# Altium schematic sheet AquaboxII_2.SchDoc
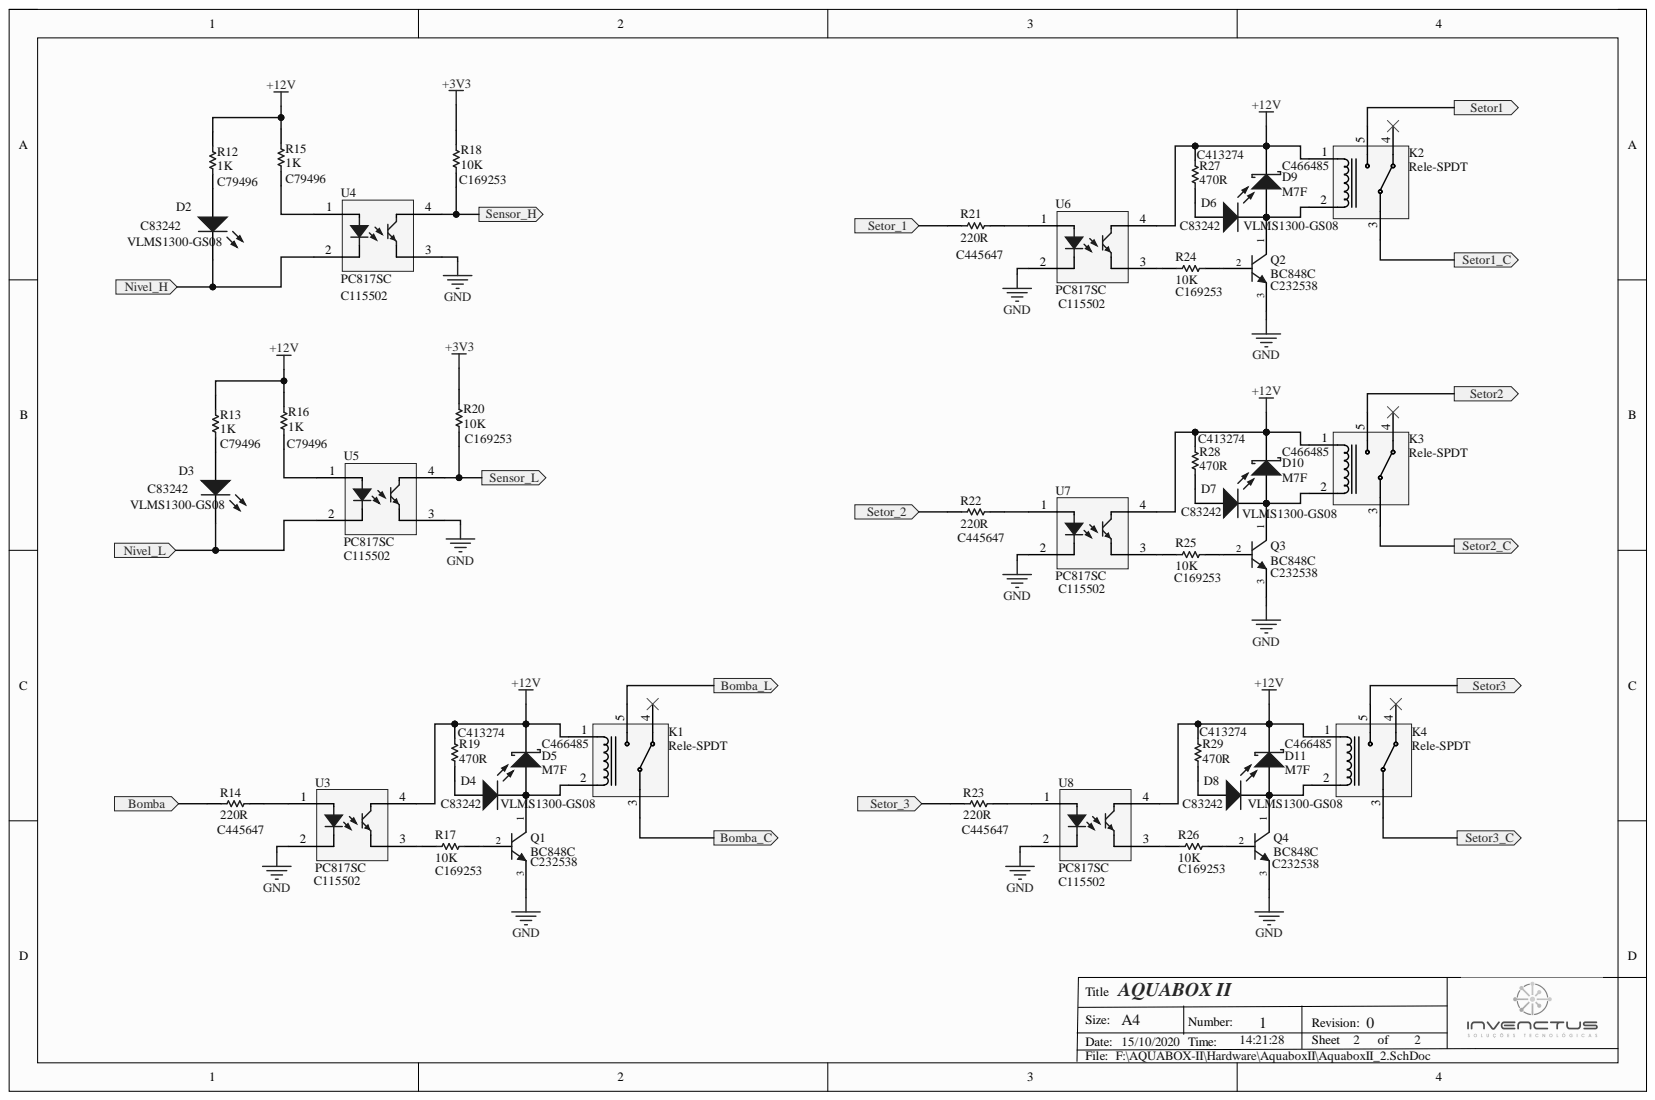
[diagram p0: AquaboxII_2.SchDoc]

## Parts
D2  VLMS1300-GS08  Supplier Part Number 1: C83242  [LED-0603-R]
D3  VLMS1300-GS08  Supplier Part Number 1: C83242  [LED-0603-R]
D4  VLMS1300-GS08  Supplier Part Number 1: C83242  [LED-0603-R]
D5  M7F  Supplier Part Number 1: C466485  [DO214AC]
D6  VLMS1300-GS08  Supplier Part Number 1: C83242  [LED-0603-R]
D7  VLMS1300-GS08  Supplier Part Number 1: C83242  [LED-0603-R]
D8  VLMS1300-GS08  Supplier Part Number 1: C83242  [LED-0603-R]
D9  M7F  Supplier Part Number 1: C466485  [DO214AC]
D10  M7F  Supplier Part Number 1: C466485  [DO214AC]
D11  M7F  Supplier Part Number 1: C466485  [DO214AC]
K1  Rele-SPDT  [RELE-SPDT]
K2  Rele-SPDT  [RELE-SPDT]
K3  Rele-SPDT  [RELE-SPDT]
K4  Rele-SPDT  [RELE-SPDT]
Q1  BC848C  Supplier Part Number 1: C232538  [SOT23]
Q2  BC848C  Supplier Part Number 1: C232538  [SOT23]
Q3  BC848C  Supplier Part Number 1: C232538  [SOT23]
Q4  BC848C  Supplier Part Number 1: C232538  [SOT23]
R12  1K  Supplier Part Number 1: C79496  [R2012[0805]]
R13  1K  Supplier Part Number 1: C79496  [R2012[0805]]
R14  220R  Supplier Part Number 1: C445647  [R2012[0805]]
R15  1K  Supplier Part Number 1: C79496  [R2012[0805]]
R16  1K  Supplier Part Number 1: C79496  [R2012[0805]]
R17  10K  [R2012[0805]]
R18  10K  Supplier Part Number 1: C169253  [R2012[0805]]
R19  470R  Supplier Part Number 1: C413274  [R2012[0805]]
R20  10K  Supplier Part Number 1: C169253  [R2012[0805]]
R21  220R  Supplier Part Number 1: C445647  [R2012[0805]]
R22  220R  Supplier Part Number 1: C445647  [R2012[0805]]
R23  220R  Supplier Part Number 1: C445647  [R2012[0805]]
R24  10K  [R2012[0805]]
R25  10K  [R2012[0805]]
R26  10K  [R2012[0805]]
R27  470R  Supplier Part Number 1: C413274  [R2012[0805]]
R28  470R  Supplier Part Number 1: C413274  [R2012[0805]]
R29  470R  Supplier Part Number 1: C413274  [R2012[0805]]
U3  PC817SC  Supplier Part Number 1: C115502  [LITEON LTV-817]
U4  PC817SC  LCSC Part: C115502  [LITEON LTV-817]
U5  PC817SC  Supplier Part Number 1: C115502  [LITEON LTV-817]
U6  PC817SC  Supplier Part Number 1: C115502  [LITEON LTV-817]
U7  PC817SC  Supplier Part Number 1: C115502  [LITEON LTV-817]
U8  PC817SC  Supplier Part Number 1: C115502  [LITEON LTV-817]

## Ports
Bomba, Bomba_C, Bomba_L, Nivel_H, Nivel_L, Sensor_H, Sensor_L, Setor1, Setor1_C, Setor2, Setor2_C, Setor3, Setor3_C, Setor_1, Setor_2, Setor_3

## Nets
+3V3: R18.2 R20.2
+12V: D5.2 D9.2 D10.2 D11.2 K1.1 K2.1 K3.1 K4.1 R12.2 R13.2 R15.2 R16.2 R19.1 R27.1 R28.1 R29.1 U3.4 U6.4 U7.4 U8.4
GND: Q1.3 Q2.3 Q3.3 Q4.3 U3.2 U4.3 U5.3 U6.2 U7.2 U8.2
Bomba: R14.2 ; port Bomba
Bomba_C: K1.3 ; port Bomba_C
Bomba_L: K1.5 ; port Bomba_L
Nivel_H: D2.2 U4.2 ; port Nivel_H
Nivel_L: D3.2 U5.2 ; port Nivel_L
Sensor_H: R18.1 U4.4 ; port Sensor_H
Sensor_L: R20.1 U5.4 ; port Sensor_L
Setor1: K2.5 ; port Setor1
Setor1_C: K2.3 ; port Setor1_C
Setor2: K3.5 ; port Setor2
Setor2_C: K3.3 ; port Setor2_C
Setor3: K4.5 ; port Setor3
Setor3_C: K4.3 ; port Setor3_C
Setor_1: R21.2 ; port Setor_1
Setor_2: R22.2 ; port Setor_2
Setor_3: R23.2 ; port Setor_3
NetD2_1: D2.1 R12.1
NetD3_1: D3.1 R13.1
NetD4_1: D4.1 R19.2
NetD4_2: D4.2 D5.1 K1.2 Q1.1
NetD6_1: D6.1 R27.2
NetD6_2: D6.2 D9.1 K2.2 Q2.1
NetD7_1: D7.1 R28.2
NetD7_2: D7.2 D10.1 K3.2 Q3.1
NetD8_1: D8.1 R29.2
NetD8_2: D8.2 D11.1 K4.2 Q4.1
NetQ1_2: Q1.2 R17.1
NetQ2_2: Q2.2 R24.1
NetQ3_2: Q3.2 R25.1
NetQ4_2: Q4.2 R26.1
NetR14_1: R14.1 U3.1
NetR15_1: R15.1 U4.1
NetR16_1: R16.1 U5.1
NetR17_2: R17.2 U3.3
NetR21_1: R21.1 U6.1
NetR22_1: R22.1 U7.1
NetR23_1: R23.1 U8.1
NetR24_2: R24.2 U6.3
NetR25_2: R25.2 U7.3
NetR26_2: R26.2 U8.3

Not connected: K1 4; K2 4; K3 4; K4 4

## Library data of the parts
D2-D4 D6-D8 = LED: LED Uni-Color Red 639nm 2-Pin Chip LED T/R; Dominant Wavelength: 631nm; Forward Current: 20mA; Forward Voltage: 2V; Lens Style: Rectangular,Clear; Luminous Intensity: 54mcd; Max Power Dissipation: 75mW; Number of Elements: 1; Reverse Voltage: 5V; Viewing Angle: 130°; Case Code (Imperial): 0603; Case Code (Metric): 1608; Case/Package: 0603; Category: LEDs; Color: Red; Component Kind: Standard; ComponentLink1Description: https://datasheet.ciiva.com/2114/vlmo1300-2114929.pdf?src-supplier=Digi-Key; ComponentLink1URL: https://datasheet.ciiva.com/2114/vlmo1300-2114929.pdf?src-supplier=Digi-Key; ComponentLink2Description: https://datasheet.ciiva.com/2114/vlmo1300-2114930.pdf?src-supplier=Digi-Key; ComponentLink2URL: https://datasheet.ciiva.com/2114/vlmo1300-2114930.pdf?src-supplier=Digi-Key; ComponentLink3Description: https://datasheet.ciiva.com/2114/vlmo1300-2114931.pdf?src-supplier=Digi-Key; ComponentLink3URL: https://datasheet.ciiva.com/2114/vlmo1300-2114931.pdf?src-supplier=Digi-Key; ComponentLink4Description: https://datasheet.ciiva.com/16510/vlmo1300-16510985.pdf?src-supplier=Future+Electronics; ComponentLink4URL: https://datasheet.ciiva.com/16510/vlmo1300-16510985.pdf?src-supplier=Future+Electronics; ComponentLink5Description: https://datasheet.ciiva.com/26322/vlmo1300-26322153.pdf?src-supplier=Element14; ComponentLink5URL: https://datasheet.ciiva.com/26322/vlmo1300-26322153.pdf?src-supplier=Element14; ComponentLink6Description: https://datasheet.ciiva.com/8028/vlmo1300-8028047.pdf?src-supplier=Element14; ComponentLink6URL: https://datasheet.ciiva.com/8028/vlmo1300-8028047.pdf?src-supplier=Element14; ComponentLink7Description: https://datasheet.ciiva.com/9333/vlmo1300-9333743.pdf?src-supplier=Avnet; ComponentLink7URL: https://datasheet.ciiva.com/9333/vlmo1300-9333743.pdf?src-supplier=Avnet; Contact Plating: Tin,Matte; Depth: 0.8mm; Height: 0.8mm; Illumination Color: Red; Lead Free: Lead Free; Length: 1.6mm; Library Name: Basic Library.IntLib; Manufacturer Part Number: VLMS1300-GS08; Max Operating Temperature: 85°C; Min Operating Temperature: -35°C; Mount: Surface Mount; Number of LEDs: 1; Number of Pins: 2; Package Quantity: 3000; Packaging: Tape and Reel; Power Dissipation: 75mW; Power Rating: 75mW; Pricing 1: 10=0,07360, 100=0,05470, 300=0,05130, 1000=0,04780, 5000=0,04630, 10000=0,04550, 10=0,06990, 100=0,05190, 300=0,04870, 1000=0,04540, 5000=0,04390, 10000=0,04320, 10=0,35640, 100=0,26490, 300=0,24820, 1000=0,23140, 5000=0,22390, 10000=0,22020, 10=0,33510, 100=0,24920, 300=0,23340, 1000=0,21760, 5000=0,21060, 10000=0,20710, 10=0,04500, 100=0,03350, 300=0,03140, 1000=0,02920, 5000=0,02830, 10000=0,02780, 10=0,04090, 100=0,03040, 300=0,02840, 1000=0,02650, 5000=0,02570, 10000=0,02520, 10=0,41250, 100=0,30670, 300=0,28730, 1000=0,26780, 5000=0,25920, 10000=0,25490, 10=5,62230, 100=4,18010, 300=3,91520, 1000=3,65030, 5000=3,53260, 10000=3,47440, 10=0,22030, 100=0,16380, 300=0,15340, 1000=0,14300, 5000=0,13840, 10000=0,13610, 10=4,09310, 100=3,04310, 300=2,85020, 1000=2,65740, 5000=2,57170, 10000=2,52940, 10=0,46820, 100=0,34810, 300=0,32610, 1000=0,30400, 5000=0,29420, 10000=0,28930, 10=0,07210, 100=0,05360, 300=0,05020, 1000=0,04680, 5000=0,04530, 10000=0,04450, 10=0,05323, 100=0,03958, 300=0,03707, 1000=0,03456, 5000=0,03345, 10000=0,03290 (AUD); Radiation Hardening: No; REACH SVHC: Unknown; RoHS: Yes; Simulation: LED0; Stock 1: 3280; Supplier 1: LCSC; Test Current: 20mA; Wavelength: 631nm; Width: 0.8mm
D5 D9-D11 = D Schottky: MICRO COMMERCIAL COMPONENTS - SM4007PL-TP - Diode mit Standard-Erholzeit, 1 kV, 1 A, Einfach, 525 mV, 25 A; Average Rectified Current: 1A; Element Configuration: Single; Forward Voltage: 1.1V; Max Forward Surge Current (Ifsm): 30A; Max Repetitive Reverse Voltage (Vrrm): 1kV; Max Reverse Current: 5uA; Peak Non-Repetitive Surge Current: 25A; Reverse Recovery Time: 1us; Category: Rectifier Diodes; Component Kind: Standard; ComponentLink1Description: https://datasheet.ciiva.com/4246/1024sm4001pl-sm4007plsod-123fl-4246411.pdf?src-supplier=Arrow+Electronics; ComponentLink1URL: https://datasheet.ciiva.com/4246/1024sm4001pl-sm4007plsod-123fl-4246411.pdf?src-supplier=Arrow+Electronics; ComponentLink2Description: https://datasheet.ciiva.com/917/sm4001pl-sm4007plsod-123fl-917185.pdf?src-supplier=Digi-Key; ComponentLink2URL: https://datasheet.ciiva.com/917/sm4001pl-sm4007plsod-123fl-917185.pdf?src-supplier=Digi-Key; ComponentLink3Description: https://datasheet.ciiva.com/917/sm4001pl-sm4007plsod-123fl-917186.pdf?src-supplier=Digi-Key; ComponentLink3URL: https://datasheet.ciiva.com/917/sm4001pl-sm4007plsod-123fl-917186.pdf?src-supplier=Digi-Key; ComponentLink4Description: https://datasheet.ciiva.com/917/sm4001pl-sm4007plsod-123fl-917187.pdf?src-supplier=Digi-Key; ComponentLink4URL: https://datasheet.ciiva.com/917/sm4001pl-sm4007plsod-123fl-917187.pdf?src-supplier=Digi-Key; ComponentLink5Description: https://datasheet.ciiva.com/7963/1684871-7963317.pdf?src-supplier=Element14; ComponentLink5URL: https://datasheet.ciiva.com/7963/1684871-7963317.pdf?src-supplier=Element14; ComponentLink6Description: https://datasheet.ciiva.com/5763/1684871-5763463.pdf?src-supplier=Farnell; ComponentLink6URL: https://datasheet.ciiva.com/5763/1684871-5763463.pdf?src-supplier=Farnell; ComponentLink7Description: https://datasheet.ciiva.com/24152/sm4001pl-sm4007plsod-123fl-24152055.pdf?src-supplier=Future+Electronics; ComponentLink7URL: https://datasheet.ciiva.com/24152/sm4001pl-sm4007plsod-123fl-24152055.pdf?src-supplier=Future+Electronics; Current: 1A; Forward Current: 1A; Lead Free: Lead Free; Library Name: Diodos.IntLib; Manufacturer Part Number: SM4007PL-TP; Max Operating Temperature: 175°C; Max Reverse Voltage (DC): 1kV; Max Surge Current: 30A; Min Operating Temperature: -65°C; Mount: Surface Mount; Number of Pins: 2; Package Quantity: 1; Packaging: Tape and Reel; Radiation Hardening: No; REACH SVHC: Unknown; Reverse Voltage: 1kV; RoHS: Yes; Signal Integrity: D Schottky; Simulation: SKYDIODE; Supplier 1: LCSC; Voltage: 1kV
Q1-Q4 = NPN: ON SEMICONDUCTOR - BC848CLT1G - Bipolar (BJT) Single Transistor, General Purpose, NPN, 30 V, 100 MHz, 300 mW, 100 mA, 100 hFE; Collector Base Voltage (VCBO): 30V; Collector Emitter Saturation Voltage: 600mV; Current Rating: 100mA; Emitter Base Voltage (VEBO): 5V; Frequency: 100MHz; hFE Min: 420; Max Power Dissipation: 300mW; Number of Elements: 1; Case/Package: SOT-23-3; Category: BJTs; Collector Emitter Breakdown Voltage: 30V; Collector Emitter Voltage (VCEO): 30V; Component Kind: Standard; ComponentLink1Description: https://datasheet.ciiva.com/4722/bc846alt1-d-108909-4722112.pdf?src-supplier=Mouser; ComponentLink1URL: https://datasheet.ciiva.com/4722/bc846alt1-d-108909-4722112.pdf?src-supplier=Mouser; ComponentLink2Description: https://datasheet.ciiva.com/15138/getdatasheetpartid-68998-15138954.pdf?src-supplier=Verical; ComponentLink2URL: https://datasheet.ciiva.com/15138/getdatasheetpartid-68998-15138954.pdf?src-supplier=Verical; ComponentLink3Description: https://datasheet.ciiva.com/976/bc846alt1-d-976404.pdf?src-supplier=Digi-Key; ComponentLink3URL: https://datasheet.ciiva.com/976/bc846alt1-d-976404.pdf?src-supplier=Digi-Key; ComponentLink4Description: https://datasheet.ciiva.com/976/bc846alt1-d-976405.pdf?src-supplier=Digi-Key; ComponentLink4URL: https://datasheet.ciiva.com/976/bc846alt1-d-976405.pdf?src-supplier=Digi-Key; ComponentLink5Description: https://datasheet.ciiva.com/16351/bc846alt1-d-16351381.pdf?src-supplier=Future+Electronics; ComponentLink5URL: https://datasheet.ciiva.com/16351/bc846alt1-d-16351381.pdf?src-supplier=Future+Electronics; ComponentLink6Description: https://datasheet.ciiva.com/976/bc846alt1-d-976406.pdf?src-supplier=Digi-Key; ComponentLink6URL: https://datasheet.ciiva.com/976/bc846alt1-d-976406.pdf?src-supplier=Digi-Key; ComponentLink7Description: https://datasheet.ciiva.com/25998/bc846alt1-d-25998630.pdf?src-supplier=Avnet+Europe; ComponentLink7URL: https://datasheet.ciiva.com/25998/bc846alt1-d-25998630.pdf?src-supplier=Avnet+Europe; ComponentLink8Description: https://datasheet.ciiva.com/11202/bc846alt1-d-11202104.pdf?src-supplier=Avnet; ComponentLink8URL: https://datasheet.ciiva.com/11202/bc846alt1-d-11202104.pdf?src-supplier=Avnet; ComponentLink9Description: https://datasheet.ciiva.com/7971/bc846alt1-d-7971964.pdf?src-supplier=Element14; ComponentLink9URL: https://datasheet.ciiva.com/7971/bc846alt1-d-7971964.pdf?src-supplier=Element14; ComponentLink10Description: https://datasheet.ciiva.com/7971/bc846alt1-d-7971966.pdf?src-supplier=Element14; ComponentLink10URL: https://datasheet.ciiva.com/7971/bc846alt1-d-7971966.pdf?src-supplier=Element14; Contact Plating: Tin,Matte; Element Configuration: Single; Gain Bandwidth Product: 100MHz; Height: 0.94mm; Lead Free: Lead Free; Length: 2.9mm; Library Name: Transistores.IntLib; Manufacturer: ON Semiconductor; Manufacturer Part Number: BC848CLT1G; Max Breakdown Voltage: 30V; Max Collector Current: 100mA; Max Frequency: 100MHz; Max Operating Temperature: 150°C; Min Operating Temperature: -55°C; Number of Pins: 3; Package Quantity: 1; Packaging: Tape and Reel; Polarity: NPN; Power Dissipation: 300mW; Pricing 1: 20=0,02450, 200=0,01770, 600=0,01650, 2000=0,01520, 10000=0,01470, 20000=0,01440, 20=0,02330, 200=0,01680, 600=0,01560, 2000=0,01440, 10000=0,01390, 20000=0,01370, 20=0,11880, 200=0,08580, 600=0,07970, 2000=0,07370, 10000=0,07100, 20000=0,06970, 20=0,11170, 200=0,08070, 600=0,07500, 2000=0,06930, 10000=0,06680, 20000=0,06550, 20=0,01500, 200=0,01080, 600=0,01010, 2000=0,00930, 10000=0,00900, 20000=0,00880, 20=0,01360, 200=0,00980, 600=0,00910, 2000=0,00840, 10000=0,00810, 20000=0,00800, 20=0,13750, 200=0,09930, 600=0,09230, 2000=0,08530, 10000=0,08220, 20000=0,08060, 20=1,87440, 200=1,35380, 600=1,25810, 2000=1,16250, 10000=1,12000, 20000=1,09900, 20=0,07340, 200=0,05300, 600=0,04930, 2000=0,04550, 10000=0,04390, 20000=0,04310, 20=1,36450, 200=0,98550, 600=0,91590, 2000=0,84630, 10000=0,81540, 20000=0,80010, 20=0,15610, 200=0,11270, 600=0,10480, 2000=0,09680, 10000=0,09330, 20000=0,09150, 20=0,02400, 200=0,01740, 600=0,01610, 2000=0,01490, 10000=0,01440, 20000=0,01410, 20=0,01775, 200=0,01282, 600=0,01191, 2000=0,01101, 10000=0,01060, 20000=0,01041 (AUD); Radiation Hardening: No; REACH SVHC: No SVHC; RoHS: Yes; Signal Integrity: NPN; Simulation: NPN; Stock 1: 17320; Supplier 1: LCSC; Transition Frequency: 100MHz; Voltage Rating (DC): 30V; Width: 1.3mm
R12 R13 R15 R16 = R: Res Thick Film 0805 1K Ohm 1% 1/8W ±100ppm/°C Molded SMD Punched Carrier T/R; Manufacturer Part Number: ERJ6ENF1001V; Max Power Dissipation: 125mW; Resistance: 1kR; Value: 0; Voltage Rating: 150V; Case Code (Imperial): 0805; Case Code (Metric): 2012; Case/Package: 0805; Category: Chip SMD Resistors; Component Kind: Standard; ComponentLink1Description: https://datasheet.ciiva.com/34303/1988962-34303619.pdf?src-supplier=Jameco; ComponentLink1URL: https://datasheet.ciiva.com/34303/1988962-34303619.pdf?src-supplier=Jameco; ComponentLink2Description: https://datasheet.ciiva.com/11808/0900766b80f80784-11808500.pdf?src-supplier=RSComponents; ComponentLink2URL: https://datasheet.ciiva.com/11808/0900766b80f80784-11808500.pdf?src-supplier=RSComponents; ComponentLink3Description: https://datasheet.ciiva.com/28532/426256-28532134.pdf?src-supplier=Element14; ComponentLink3URL: https://datasheet.ciiva.com/28532/426256-28532134.pdf?src-supplier=Element14; ComponentLink4Description: https://datasheet.ciiva.com/16774/70260748-16774249.pdf?src-supplier=Allied+Electronics; ComponentLink4URL: https://datasheet.ciiva.com/16774/70260748-16774249.pdf?src-supplier=Allied+Electronics; ComponentLink5Description: https://datasheet.ciiva.com/46373/1678782-46373338.pdf?src-supplier=Element14; ComponentLink5URL: https://datasheet.ciiva.com/46373/1678782-46373338.pdf?src-supplier=Element14; ComponentLink6Description: https://datasheet.ciiva.com/7942/426256-7942429.pdf?src-supplier=Element14; ComponentLink6URL: https://datasheet.ciiva.com/7942/426256-7942429.pdf?src-supplier=Element14; ComponentLink7Description: https://datasheet.ciiva.com/9117/aoa0000ce2-9117391.pdf?src-supplier=Avnet; ComponentLink7URL: https://datasheet.ciiva.com/9117/aoa0000ce2-9117391.pdf?src-supplier=Avnet; ComponentLink8Description: https://datasheet.ciiva.com/16523/aoa0000ce2-16523855.pdf?src-supplier=Avnet+Asia; ComponentLink8URL: https://datasheet.ciiva.com/16523/aoa0000ce2-16523855.pdf?src-supplier=Avnet+Asia; ComponentLink9Description: https://datasheet.ciiva.com/30051/aoa0000ce2-30051974.pdf?src-supplier=Onlinecomponents.com; ComponentLink9URL: https://datasheet.ciiva.com/30051/aoa0000ce2-30051974.pdf?src-supplier=Onlinecomponents.com; ComponentLink10Description: https://datasheet.ciiva.com/16199/aoa0000ce2-16199262.pdf?src-supplier=Future+Electronics; ComponentLink10URL: https://datasheet.ciiva.com/16199/aoa0000ce2-16199262.pdf?src-supplier=Future+Electronics; Contact Plating: Tin; Depth: 1.25mm; Features: Automotive AEC-Q200; Height: 0.6mm; Lead Free: Lead Free; Length: 2mm; Max Operating Temperature: 155°C; Military Standard: Not; Min Operating Temperature: -55°C; Mount: Surface Mount; Number of Pins: 2; Number of Terminations: 2; Package Quantity: 5000; Packaging: Tape and Reel; Power Rating: 125mW; Pricing 1: 50=0,01660, 500=0,01220, 1500=0,01140, 5000=0,01060, 25000=0,01020, 50000=0,01000, 50=0,01570, 500=0,01160, 1500=0,01080, 5000=0,01000, 25000=0,00970, 50000=0,00950, 50=0,08020, 500=0,05900, 1500=0,05510, 5000=0,05110, 25000=0,04940, 50000=0,04850, 50=0,07550, 500=0,05540, 1500=0,05180, 5000=0,04810, 25000=0,04650, 50000=0,04570, 50=0,01010, 500=0,00750, 1500=0,00700, 5000=0,00650, 25000=0,00620, 50000=0,00610, 50=0,00920, 500=0,00680, 1500=0,00630, 5000=0,00590, 25000=0,00570, 50000=0,00560, 50=0,09290, 500=0,06830, 1500=0,06370, 5000=0,05920, 25000=0,05720, 50000=0,05620, 50=1,26610, 500=0,93020, 1500=0,86850, 5000=0,80680, 25000=0,77950, 50000=0,76590, 50=0,04960, 500=0,03640, 1500=0,03400, 5000=0,03160, 25000=0,03050, 50000=0,03000, 50=0,92170, 500=0,67720, 1500=0,63230, 5000=0,58740, 25000=0,56750, 50000=0,55750, 50=0,10540, 500=0,07750, 1500=0,07230, 5000=0,06720, 25000=0,06490, 50000=0,06380, 50=0,01620, 500=0,01190, 1500=0,01110, 5000=0,01030, 25000=0,01000, 50000=0,00980, 50=0,01199, 500=0,00881, 1500=0,00822, 5000=0,00764, 25000=0,00738, 50000=0,00725 (AUD); Radiation Hardening: No; REACH SVHC: No SVHC; Resistor Type: Thick Film; RoHS: Yes; Signal Integrity: Res Semi; Simulation: RES; Stock 1: 5600; Supplier 1: LCSC; Temperature Coefficient: 100ppm/°C; Termination: SMD/SMT; Tolerance: 1%; Voltage Rating (DC): 150V; Width: 1.2mm; Library Name: Resistores.IntLib
R14 R21-R23 = R: Thin Film Resistors - SMD 0805 220ohm 1/8W .1% 25ppm; Manufacturer Part Number: ERA-6AEB221V; Max Power Dissipation: 125mW; Temperature Coefficient: 25ppm/°C; Tolerance: 0.1%; Value: 0; Voltage Rating: 100V; Case Code (Imperial): 0805; Case Code (Metric): 2012; Case/Package: 0805; Category: Chip SMD Resistors; Component Kind: Standard; ComponentLink1Description: https://datasheet.ciiva.com/11811/0900766b80beefcc-11811386.pdf?src-supplier=RSComponents; ComponentLink1URL: https://datasheet.ciiva.com/11811/0900766b80beefcc-11811386.pdf?src-supplier=RSComponents; ComponentLink2Description: https://datasheet.ciiva.com/29184/452802-da-01-en-widerstand-0805-era-29184070.pdf?src-supplier=Conrad; ComponentLink2URL: https://datasheet.ciiva.com/29184/452802-da-01-en-widerstand-0805-era-29184070.pdf?src-supplier=Conrad; ComponentLink3Description: https://datasheet.ciiva.com/6138/485442-6138581.pdf?src-supplier=Farnell; ComponentLink3URL: https://datasheet.ciiva.com/6138/485442-6138581.pdf?src-supplier=Farnell; ComponentLink4Description: https://datasheet.ciiva.com/9184/aoa0000ce26-9184326.pdf?src-supplier=Avnet; ComponentLink4URL: https://datasheet.ciiva.com/9184/aoa0000ce26-9184326.pdf?src-supplier=Avnet; ComponentLink5Description: https://datasheet.ciiva.com/46445/485442-46445769.pdf?src-supplier=Element14; ComponentLink5URL: https://datasheet.ciiva.com/46445/485442-46445769.pdf?src-supplier=Element14; ComponentLink6Description: https://datasheet.ciiva.com/46445/485442-46445770.pdf?src-supplier=Element14; ComponentLink6URL: https://datasheet.ciiva.com/46445/485442-46445770.pdf?src-supplier=Element14; ComponentLink7Description: https://datasheet.ciiva.com/48536/era-eng-tds-48536637.pdf?src-supplier=Distrelec; ComponentLink7URL: https://datasheet.ciiva.com/48536/era-eng-tds-48536637.pdf?src-supplier=Distrelec; ComponentLink8Description: https://datasheet.ciiva.com/6138/485442-6138575.pdf?src-supplier=Farnell; ComponentLink8URL: https://datasheet.ciiva.com/6138/485442-6138575.pdf?src-supplier=Farnell; Contact Plating: Tin; Depth: 1.25mm; Features: Automotive AEC-Q200; Height: 0.024inch; Lead Free: Lead Free; Length: 2mm; Max Operating Temperature: 155°C; Military Standard: Not; Min Operating Temperature: -55°C; Mount: Surface Mount; Number of Pins: 2; Number of Terminations: 2; Package Quantity: 1; Packaging: Tape and Reel; Power Rating: 125mW; Pricing 1: 5=0,09530, 50=0,07000, 150=0,06530, 500=0,06060, 2500=0,05860, 5000=0,05750, 5=0,09050, 50=0,06640, 150=0,06200, 500=0,05750, 2500=0,05560, 5000=0,05460, 5=0,46150, 50=0,33860, 150=0,31610, 500=0,29350, 2500=0,28350, 5000=0,27850, 5=0,43400, 50=0,31850, 150=0,29720, 500=0,27600, 2500=0,26660, 5000=0,26190, 5=0,05830, 50=0,04280, 150=0,03990, 500=0,03710, 2500=0,03580, 5000=0,03520, 5=0,05290, 50=0,03880, 150=0,03620, 500=0,03360, 2500=0,03250, 5000=0,03190, 5=0,53430, 50=0,39200, 150=0,36590, 500=0,33980, 2500=0,32820, 5000=0,32240, 5=7,28120, 50=5,34250, 150=4,98650, 500=4,63040, 2500=4,47220, 5000=4,39400, 5=0,28530, 50=0,20930, 150=0,19540, 500=0,18140, 2500=0,17520, 5000=0,17210, 5=5,30070, 50=3,88940, 150=3,63020, 500=3,37100, 2500=3,25580, 5000=3,19880, 5=0,60640, 50=0,44490, 150=0,41530, 500=0,38560, 2500=0,37240, 5000=0,36590, 5=0,09330, 50=0,06850, 150=0,06390, 500=0,05940, 2500=0,05730, 5000=0,05630, 5=0,06894, 50=0,05058, 150=0,04721, 500=0,04384, 2500=0,04234, 5000=0,04160 (AUD); Radiation Hardening: No; REACH SVHC: No SVHC; Resistance: 220R; Resistor Type: Thin Film; RoHS: Yes; Signal Integrity: Res Semi; Simulation: RES; Stock 1: 8250; Supplier 1: LCSC; Termination: SMD/SMT; Voltage Rating (DC): 100V; Width: 0.049inch; Library Name: Resistores.IntLib
R17 R18 R20 R24-R26 = R: PANASONIC - ERJ6ENF1002V - Ytmonterat chipmotstånd, Tjockfilm, 0805 [2012 Metrisk], 10 kohm, ERJ6E Serien, 150 V, Tjockfilm; Manufacturer Part Number: ERJ-6ENF1002V; Max Power Dissipation: 125mW; Resistance: 10kR; Temperature Coefficient: 100ppm/°C; Tolerance: 1%; Value: 0; Voltage Rating: 150V; Case Code (Imperial): 0805; Case Code (Metric): 2012; Case/Package: 0805; Category: Chip SMD Resistors; Component Kind: Standard; ComponentLink1Description: https://datasheet.ciiva.com/11808/0900766b80f80784-11808080.pdf?src-supplier=RSComponents; ComponentLink1URL: https://datasheet.ciiva.com/11808/0900766b80f80784-11808080.pdf?src-supplier=RSComponents; ComponentLink2Description: https://datasheet.ciiva.com/16514/aoa0000ce2-16514021.pdf?src-supplier=Avnet+Asia; ComponentLink2URL: https://datasheet.ciiva.com/16514/aoa0000ce2-16514021.pdf?src-supplier=Avnet+Asia; ComponentLink3Description: https://datasheet.ciiva.com/27055/1988971-27055733.pdf?src-supplier=Jameco; ComponentLink3URL: https://datasheet.ciiva.com/27055/1988971-27055733.pdf?src-supplier=Jameco; ComponentLink4Description: https://datasheet.ciiva.com/16759/70260748-16759350.pdf?src-supplier=Allied+Electronics; ComponentLink4URL: https://datasheet.ciiva.com/16759/70260748-16759350.pdf?src-supplier=Allied+Electronics; ComponentLink5Description: https://datasheet.ciiva.com/16725/70260748-16725031.pdf?src-supplier=Allied+Electronics; ComponentLink5URL: https://datasheet.ciiva.com/16725/70260748-16725031.pdf?src-supplier=Allied+Electronics; ComponentLink6Description: https://datasheet.ciiva.com/46372/1678782-46372714.pdf?src-supplier=Element14; ComponentLink6URL: https://datasheet.ciiva.com/46372/1678782-46372714.pdf?src-supplier=Element14; ComponentLink7Description: https://datasheet.ciiva.com/46372/1678782-46372715.pdf?src-supplier=Element14; ComponentLink7URL: https://datasheet.ciiva.com/46372/1678782-46372715.pdf?src-supplier=Element14; Contact Plating: Tin; Depth: 1.25mm; Features: Automotive AEC-Q200; Height: 0.6mm; Lead Free: Lead Free; Length: 2mm; Max Operating Temperature: 155°C; Military Standard: Not; Min Operating Temperature: -55°C; Mount: Surface Mount; Number of Pins: 2; Number of Terminations: 2; Package Quantity: 5000; Packaging: Tape and Reel; Power Rating: 125mW; Pricing 1: 50=0,01490, 500=0,01100, 1500=0,01030, 5000=0,00950, 25000=0,00920, 50000=0,00900, 50=0,01420, 500=0,01040, 1500=0,00970, 5000=0,00900, 25000=0,00870, 50000=0,00860, 50=0,07230, 500=0,05320, 1500=0,04960, 5000=0,04610, 25000=0,04460, 50000=0,04380, 50=0,06800, 500=0,05000, 1500=0,04670, 5000=0,04340, 25000=0,04190, 50000=0,04120, 50=0,00910, 500=0,00670, 1500=0,00630, 5000=0,00580, 25000=0,00560, 50000=0,00550, 50=0,00830, 500=0,00610, 1500=0,00570, 5000=0,00530, 25000=0,00510, 50000=0,00500, 50=0,08370, 500=0,06150, 1500=0,05750, 5000=0,05340, 25000=0,05160, 50000=0,05070, 50=1,14020, 500=0,83860, 1500=0,78320, 5000=0,72780, 25000=0,70310, 50000=0,69100, 50=0,04470, 500=0,03290, 1500=0,03070, 5000=0,02850, 25000=0,02750, 50000=0,02710, 50=0,83010, 500=0,61050, 1500=0,57020, 5000=0,52990, 25000=0,51190, 50000=0,50300, 50=0,09500, 500=0,06980, 1500=0,06520, 5000=0,06060, 25000=0,05860, 50000=0,05750, 50=0,01460, 500=0,01080, 1500=0,01000, 5000=0,00930, 25000=0,00900, 50000=0,00890, 50=0,01080, 500=0,00794, 1500=0,00742, 5000=0,00689, 25000=0,00666, 50000=0,00654 (AUD); Radiation Hardening: No; REACH SVHC: No SVHC; Resistor Type: Thick Film; RoHS: Yes; Signal Integrity: Res Semi; Simulation: RES; Stock 1: 40250; Supplier 1: LCSC; Termination: SMD/SMT; Voltage Rating (DC): 150V; Width: 0.049inch; Working Voltage: 150V; Library Name: Resistores.IntLib
  R17 R24-R26: Supplier Part Number 1: C169253
R19 R27-R29 = R: PANASONIC - ERJ6ENF4700V - RES, 470R, 1%, 0.125W, 0805, THICK FILM; Manufacturer Part Number: ERJ-6ENF4700V; Max Power Dissipation: 125mW; Temperature Coefficient: 100ppm/°C; Value: 0; Voltage Rating: 150V; Case Code (Imperial): 0805; Case Code (Metric): 2012; Case/Package: 0805; Category: Chip SMD Resistors; Component Kind: Standard; ComponentLink1Description: https://datasheet.ciiva.com/11821/0900766b80f80784-11821642.pdf?src-supplier=RSComponents; ComponentLink1URL: https://datasheet.ciiva.com/11821/0900766b80f80784-11821642.pdf?src-supplier=RSComponents; ComponentLink2Description: https://datasheet.ciiva.com/34303/1989332-34303633.pdf?src-supplier=Jameco; ComponentLink2URL: https://datasheet.ciiva.com/34303/1989332-34303633.pdf?src-supplier=Jameco; ComponentLink3Description: https://datasheet.ciiva.com/22687/1678782-22687451.pdf?src-supplier=Element14; ComponentLink3URL: https://datasheet.ciiva.com/22687/1678782-22687451.pdf?src-supplier=Element14; ComponentLink4Description: https://datasheet.ciiva.com/9181/aoa0000ce2-9181798.pdf?src-supplier=Avnet; ComponentLink4URL: https://datasheet.ciiva.com/9181/aoa0000ce2-9181798.pdf?src-supplier=Avnet; ComponentLink5Description: https://datasheet.ciiva.com/16767/70260748-16767755.pdf?src-supplier=Allied+Electronics; ComponentLink5URL: https://datasheet.ciiva.com/16767/70260748-16767755.pdf?src-supplier=Allied+Electronics; ComponentLink6Description: https://datasheet.ciiva.com/24124/aoa0000ce2-24124316.pdf?src-supplier=Mouser; ComponentLink6URL: https://datasheet.ciiva.com/24124/aoa0000ce2-24124316.pdf?src-supplier=Mouser; ComponentLink7Description: https://datasheet.ciiva.com/7830/426256-7830749.pdf?src-supplier=Element14; ComponentLink7URL: https://datasheet.ciiva.com/7830/426256-7830749.pdf?src-supplier=Element14; ComponentLink8Description: https://datasheet.ciiva.com/16783/70260748-16783246.pdf?src-supplier=Allied+Electronics; ComponentLink8URL: https://datasheet.ciiva.com/16783/70260748-16783246.pdf?src-supplier=Allied+Electronics; ComponentLink9Description: https://datasheet.ciiva.com/7830/1678782-7830991.pdf?src-supplier=Element14; ComponentLink9URL: https://datasheet.ciiva.com/7830/1678782-7830991.pdf?src-supplier=Element14; ComponentLink10Description: https://datasheet.ciiva.com/17205/aoa0000ce2-17205551.pdf?src-supplier=Avnet+Europe; ComponentLink10URL: https://datasheet.ciiva.com/17205/aoa0000ce2-17205551.pdf?src-supplier=Avnet+Europe; ComponentLink11Description: https://datasheet.ciiva.com/22668/1678782-22668335.pdf?src-supplier=Element14; ComponentLink11URL: https://datasheet.ciiva.com/22668/1678782-22668335.pdf?src-supplier=Element14; Contact Plating: Tin; Depth: 1.25mm; Features: Automotive AEC-Q200; Height: 0.6mm; Lead Free: Lead Free; Length: 2mm; Max Operating Temperature: 155°C; Military Standard: Not; Min Operating Temperature: -55°C; Mount: Surface Mount; Number of Pins: 2; Number of Terminations: 2; Package Quantity: 1; Packaging: Tape and Reel; Power Rating: 125mW; Pricing 1: 50=0,01500, 500=0,01100, 1500=0,01030, 5000=0,00950, 25000=0,00920, 50000=0,00900, 50=0,01420, 500=0,01040, 1500=0,00970, 5000=0,00910, 25000=0,00870, 50000=0,00860, 50=0,07260, 500=0,05330, 1500=0,04970, 5000=0,04620, 25000=0,04460, 50000=0,04380, 50=0,06830, 500=0,05010, 1500=0,04670, 5000=0,04340, 25000=0,04190, 50000=0,04120, 50=0,00920, 500=0,00670, 1500=0,00630, 5000=0,00580, 25000=0,00560, 50000=0,00550, 50=0,00830, 500=0,00610, 1500=0,00570, 5000=0,00530, 25000=0,00510, 50000=0,00500, 50=0,08400, 500=0,06170, 1500=0,05750, 5000=0,05340, 25000=0,05160, 50000=0,05070, 50=1,14510, 500=0,84020, 1500=0,78420, 5000=0,72830, 25000=0,70330, 50000=0,69110, 50=0,04490, 500=0,03290, 1500=0,03070, 5000=0,02850, 25000=0,02760, 50000=0,02710, 50=0,83370, 500=0,61170, 1500=0,57090, 5000=0,53020, 25000=0,51200, 50000=0,50310, 50=0,09540, 500=0,07000, 1500=0,06530, 5000=0,06060, 25000=0,05860, 50000=0,05760, 50=0,01470, 500=0,01080, 1500=0,01010, 5000=0,00930, 25000=0,00900, 50000=0,00890, 50=0,01084, 500=0,00796, 1500=0,00743, 5000=0,00690, 25000=0,00666, 50000=0,00654 (AUD); Radiation Hardening: No; REACH SVHC: No SVHC; Resistance: 470R; Resistor Type: Thick Film; RoHS: Yes; Signal Integrity: Res Semi; Simulation: RES; Stock 1: 32200; Supplier 1: LCSC; Termination: SMD/SMT; Tolerance: 1%; Voltage Rating (DC): 150V; Weight: 0.000194oz; Width: 0.049inch; Library Name: Resistores.IntLib
U3-U8 = PC817SC: PhotoAcoplador SMD-4; Component Kind: Standard; Library Name: Optos.IntLib
  U4: Mfr.Part: PC817SC; Supplier Part Number 1: C115502
K1-K4 = Rele-SPDT: Component Kind: Standard; Library Name: Components Library.IntLib
